# Altium schematic sheet 15V Regulated Power Supply.SchDoc
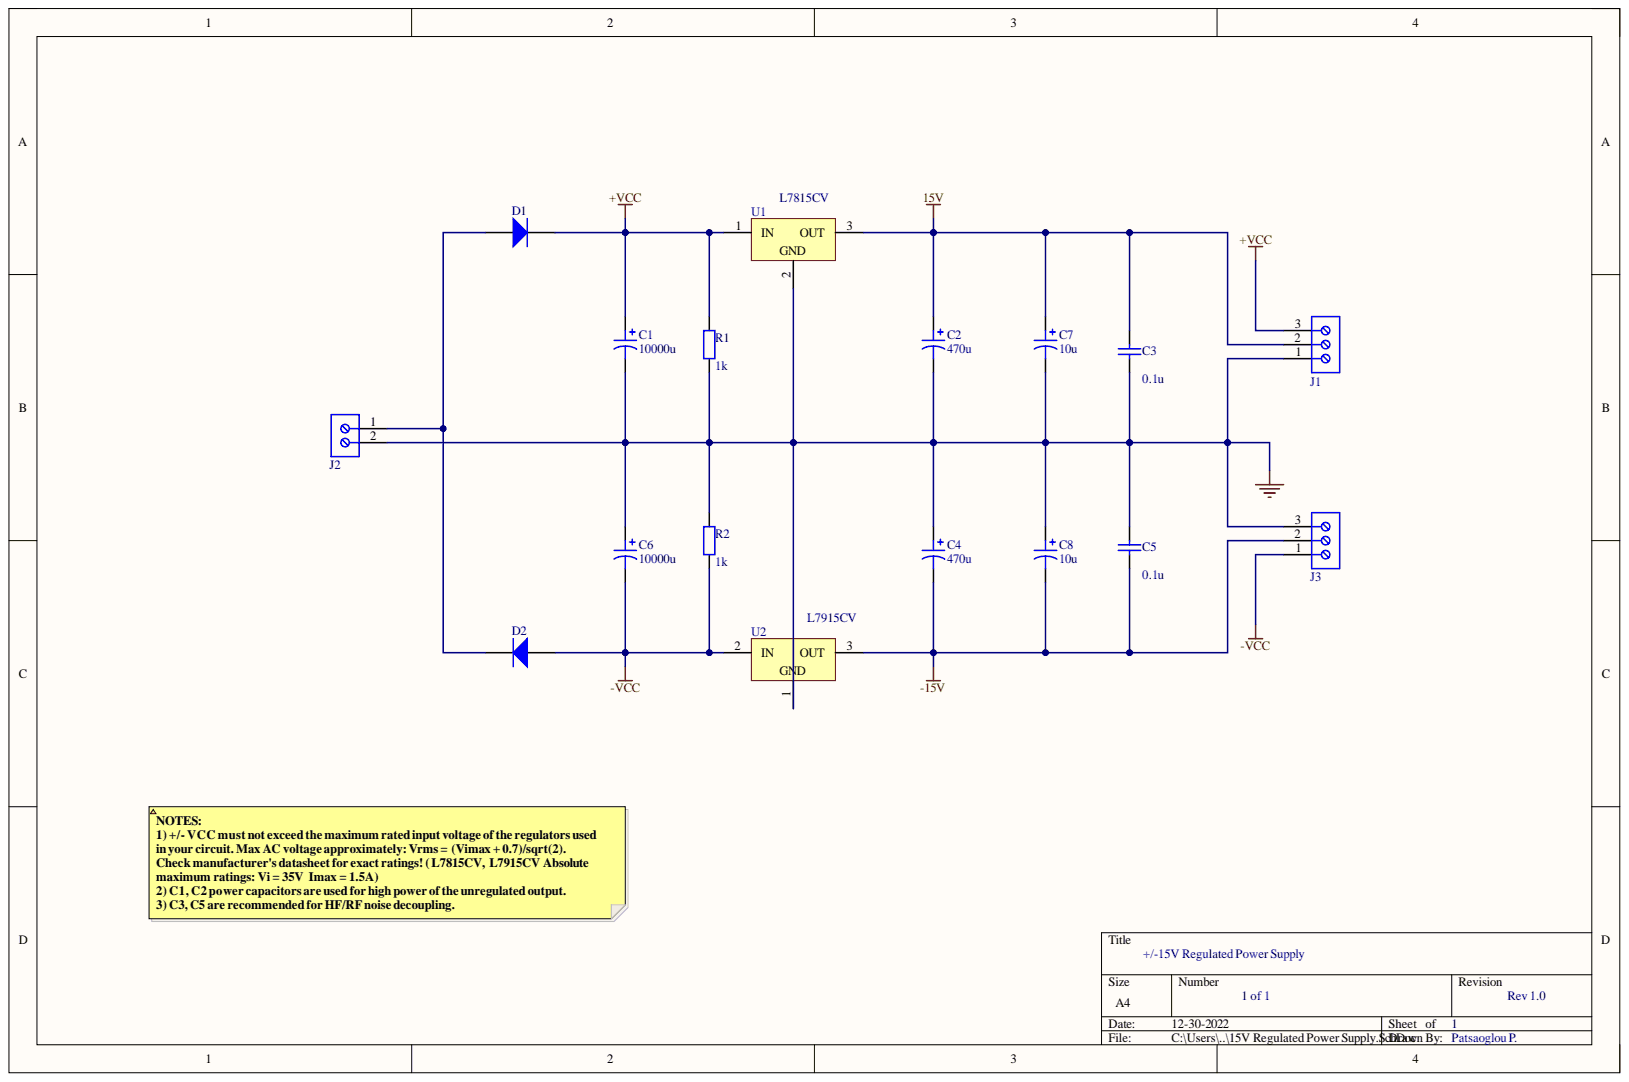
[diagram p0: 15V Regulated Power Supply.SchDoc]

## Parts
C1  10000u  [CAP TH ALUM ELEC D25.00mm S10.00mm H40.00mm]
C2  470u  [CAP TH ALUM ELEC D10.00mm S5.00mm H14.00mm]
C3  value 0.1u  [RAD-0.3]  (hidden comment: Cap)
C4  470u  [CAP TH ALUM ELEC D10.00mm S5.00mm H14.00mm]
C5  value 0.1u  [RAD-0.3]  (hidden comment: Cap)
C6  10000u  [CAP TH ALUM ELEC D25.00mm S10.00mm H40.00mm]
C7  10u  [CAP TH ALUM ELEC D5.00mm S2.00mm H11.00mm]
C8  10u  [CAP TH ALUM ELEC D5.00mm S2.00mm H11.00mm]
D1  1N4007  [DO-41]  (value not drawn)
D2  1N4007  [DO-41]  (value not drawn)
J1  691103110003  [691103110003]  (value not drawn)
J2  691103110002  [691103110002]  (value not drawn)
J3  691103110003  [691103110003]  (value not drawn)
R1  value 1k  [AXIAL-0.4]  (hidden comment: Res2)
R2  value 1k  [AXIAL-0.4]  (hidden comment: Res2)
U1  L7815CV  [TO220]
U2  L7915CV  [TO-220]

## Nets
15V: C2.1 C3.1 C7.1 J1.2 U1.3
+VCC: C1.1 D1.2 J1.3 R1.2 U1.1
-15V: C4.2 C5.2 C8.2 J3.2 U2.3
-VCC: C6.2 D2.1 J3.1 R2.1 U2.2
GND: C1.2 C2.2 C3.2 C4.1 C5.1 C6.1 C7.2 C8.1 J1.1 J2.2 J3.3 R1.1 R2.2 U1.2 U2.1
NetD1_1: D1.1 D2.2 J2.1

## Library data of the parts
C1 C6 = SLPX103M035C7P3: CAP ALUM 10000UF 20% 35V SNAP; manufacturer: Cornell Dubilier Electronics (CDE); Capacitance: 10000µF; Database Table Name: Capacitors - Aluminum - Electrolytic TH; ESR (Equivalent Series Resistance): 53mOhm @ 120Hz; Lifetime @ Temp.: 3000 Hrs @ 85°C; Polarization: Polar; Ripple Current @ High Frequency: 4.56A @ 20kHz; Ripple Current @ Low Frequency: 3.65A @ 120Hz; value: 10000µF; Applications: General Purpose; Component Kind: Standard; componentlink1description: Datasheet; componentlink1url: http://www.cde.com/resources/catalogs/SLPX.pdf; componentlink2description: DigiKey Link; componentlink2url: http://digikey.com/product-detail/en/cornell-dubilier-electronics-cde/SLPX103M035C7P3/338-1566-ND/1882043; Device Package: Radial, Can - Snap-In; Height - Seated (Max): 1.575" (40.00mm); lastupdated: 03-Sep-20 12:12:19 AM; Lead Spacing: 0.394" (10.00mm); Library Name: Celestial Altium Library - altium_library.DbLib; Lifecycle Status: Active; Minimum Order: 1; Mounting Type: Through Hole; Operating Temperature: -40°C ~ 85°C; Package / Case: Radial, Can - Snap-In; packaging: Bulk; Part Number: SLPX103M035C7P3; Part Status: Active; partid: 19491; price: 3.30; series: SLPX; Size / Dimension: 0.984" Dia (25.00mm); Supplier 1: DigiKey; Supplier Part Number 1: 338-1566-ND; Tolerance: ±20%; Voltage - Rated: 35V
C2 C4 = EEU-FK1E471: AL LYTIC, RADIAL, 25VDC, 470UF; manufacturer: Panasonic Electronic Components; Capacitance: 470µF; Database Table Name: Capacitors - Aluminum - Electrolytic TH; Impedance: 63 mOhms; Lifetime @ Temp.: 4000 Hrs @ 105°C; Polarization: Polar; Ripple Current @ High Frequency: 900mA @ 100kHz; Ripple Current @ Low Frequency: 675mA @ 120Hz; Tolerance: ±20%; value: 470µF; Voltage - Rated: 25V; Applications: Automotive; Component Kind: Standard; componentlink1description: Datasheet; componentlink1url: https://industrial.panasonic.com/cdbs/www-data/pdf/RDF0000/ABA0000C1015.pdf; componentlink2description: DigiKey Link; componentlink2url: http://digikey.com/product-detail/en/panasonic-electronic-components/EEU-FK1E471/P124304-ND/9921253; Device Package: Radial, Can; Height - Seated (Max): 0.551" (14.00mm); lastupdated: 02-Sep-20 1:31:10 AM; Lead Spacing: 0.197" (5.00mm); Library Name: Celestial Altium Library - altium_library.DbLib; Lifecycle Status: Active; Minimum Order: 1; Mounting Type: Through Hole; Operating Temperature: -55°C ~ 105°C; Package / Case: Radial, Can; packaging: Bulk; Part Number: EEU-FK1E471; Part Status: Active; partid: 10966; price: 0.65; Ratings: AEC-Q200; series: FK; Size / Dimension: 0.394" Dia (10.00mm); Supplier 1: DigiKey; Supplier Part Number 1: P124304-ND
C3 C5 = Cap: Capacitor; Component Kind: Standard; LatestRevisionDate: 17-Jul-2002; LatestRevisionNote: Re-released for DXP Platform.; Library Name: Miscellaneous Devices.IntLib; PackageDescription: Radial Cap, Thru-Hole; 2 Leads; 0.3 in Pin Spacing; PackageReference: RAD-0.3; Published: 8-Jun-2000; Signal Integrity: Cap; Simulation: CAP; Publisher: Altium Limited
C7 C8 = RFS-25V100ME3#5: CAP ALUM 10UF 20% 25V RADIAL; manufacturer: Elna America; Capacitance: 10µF; Database Table Name: Capacitors - Aluminum - Electrolytic TH; Lifetime @ Temp.: 1000 Hrs @ 85°C; Polarization: Polar; Ripple Current @ Low Frequency: 35mA @ 120Hz; value: 10µF; Applications: Audio; Component Kind: Standard; componentlink1description: Datasheet; componentlink1url: http://www.elna.co.jp/en/capacitor/alumi/catalog/pdf/rfs_e.pdf; componentlink2description: DigiKey Link; componentlink2url: http://digikey.com/product-detail/en/elna-america/RFS-25V100ME3-5/604-1050-ND/970211; Device Package: Radial, Can; Height - Seated (Max): 0.433" (11.00mm); lastupdated: 04-Sep-20 11:28:56 PM; Lead Spacing: 0.079" (2.00mm); Library Name: Celestial Altium Library - altium_library.DbLib; Lifecycle Status: Active; Minimum Order: 1; Mounting Type: Through Hole; Operating Temperature: -40°C ~ 85°C; Package / Case: Radial, Can; packaging: Bulk; Part Number: RFS-25V100ME3#5; Part Status: Active; partid: 21464; price: 0.30; series: RFS, SILMIC II; Size / Dimension: 0.197" Dia (5.00mm); Supplier 1: DigiKey; Supplier Part Number 1: 604-1050-ND; Tolerance: ±20%; Voltage - Rated: 25V
D1 D2 = Diode 1N4007: 1 Amp General Purpose Rectifier; Code_JEDEC: DO-204-AL; Component Kind: Standard; ComponentLink1Description: Manufacturer Link; ComponentLink1URL: http://www.fairchildsemi.com/; ComponentLink2Description: Datasheet; ComponentLink2URL: http://www.fairchildsemi.com/ds/1N%2F1N4001.pdf; DatasheetVersion: 1998; LatestRevisionDate: 17-Jul-2002; LatestRevisionNote: Re-released for DXP Platform.; Library Name: Miscellaneous Devices.IntLib; PackageDescription: Diode, Axial; Body 5.2 x 2.7 mm (LxDia. max), Lead Dia. 0.86 mm (max); PackageReference: DO-41; PackageVersion: Aug-1999; Published: 8-Jun-2000; Signal Integrity: 1N4007; Simulation: 1N4007; Publisher: Altium Limited
J1 J3 = CMP-1502-03255-1: Series 1031 - 3.50 mm Horizontal Entry Modular with Pressure Clamp WR-TBL, 3 pin; Manufacturer: Wurth Elektronik; Pin Number: 3; Pitch: 3.50mm; Component Kind: Standard; Datasheet URL: http://katalog.we-online.de/em/datasheet/6911031100xx.pdf; Library Name: Altium Content Vault; Manufacturer URL: http://www.we-online.de; Mounting Technology: THT; Operating Temperature: -40to105degC; Package Reference: 691103110003; Packaging: Box; Part Number: 691103110003; Publisher: WurthElektronik
J2 = CMP-1502-03254-1: Series 1031 - 3.50 mm Horizontal Entry Modular with Pressure Clamp WR-TBL, 2 pin; Manufacturer: Wurth Elektronik; Pin Number: 2; Pitch: 3.50mm; Component Kind: Standard; Datasheet URL: http://katalog.we-online.de/em/datasheet/6911031100xx.pdf; Library Name: Altium Content Vault; Manufacturer URL: http://www.we-online.de; Mounting Technology: THT; Operating Temperature: -40to105degC; Package Reference: 691103110002; Packaging: Box; Part Number: 691103110002; Publisher: WurthElektronik
R1 R2 = Res2: Resistor; Component Kind: Standard; LatestRevisionDate: 17-Jul-2002; LatestRevisionNote: Re-released for DXP Platform.; Library Name: Miscellaneous Devices.IntLib; PackageDescription: Axial Device, Thru-Hole; 2 Leads; 0.4 in Pin Spacing; PackageReference: AXIAL-0.4; Published: 8-Jun-2000; Signal Integrity: Res; Simulation: RESISTOR; Publisher: Altium Limited
U1 = CMP-0244-00422-1: Positive Voltage Regulator, 15V, 3-Pin TO-220; Component Kind: Standard; ComponentLink1Description: Manufacturer URL; ComponentLink1URL: http://www.st.com; ComponentLink2Description: Datasheet; ComponentLink2URL: http://www.st.com/internet/com/TECHNICAL_RESOURCES/TECHNICAL_LITERATURE/DATASHEET/CD00000444.pdf; ComponentLink3Description: Package Specification; ComponentLink3URL: http://www.st.com/internet/com/TECHNICAL_RESOURCES/TECHNICAL_LITERATURE/PACKAGE_INFORMATION/CD00004649.pdf; DatasheetVersion: 16/11/2011; Library Name: Altium Content Vault; PackageDescription: TO, Thru-Hole, Vertical, Heatsink Mounted; 3 In-Line Leads; Pitch 2.54 mm; PackageReference: TO220; PackageVersion: ver3.0
U2 = CMP-0244-00473-1: Negative Voltage Regulator, -15V, 3-Pin D2PAK; Component Kind: Standard; ComponentLink1Description: Manufacturer URL; ComponentLink1URL: http://www.st.com; ComponentLink2Description: Datasheet; ComponentLink2URL: http://www.st.com/internet/com/TECHNICAL_RESOURCES/TECHNICAL_LITERATURE/DATASHEET/CD00000450.pdf; DatasheetVersion: 1/11/2011; Library Name: Altium Content Vault; PackageDescription: TO, Thru-Hole, Vertical, Heatsink Mounted; 3 In-Line Leads; Pitch 2.54 mm; PackageReference: TO-220; PackageVersion: 2011
